# Altium schematic sheet NandFlash Board(A).SchDoc("NandFlash Board(A)")
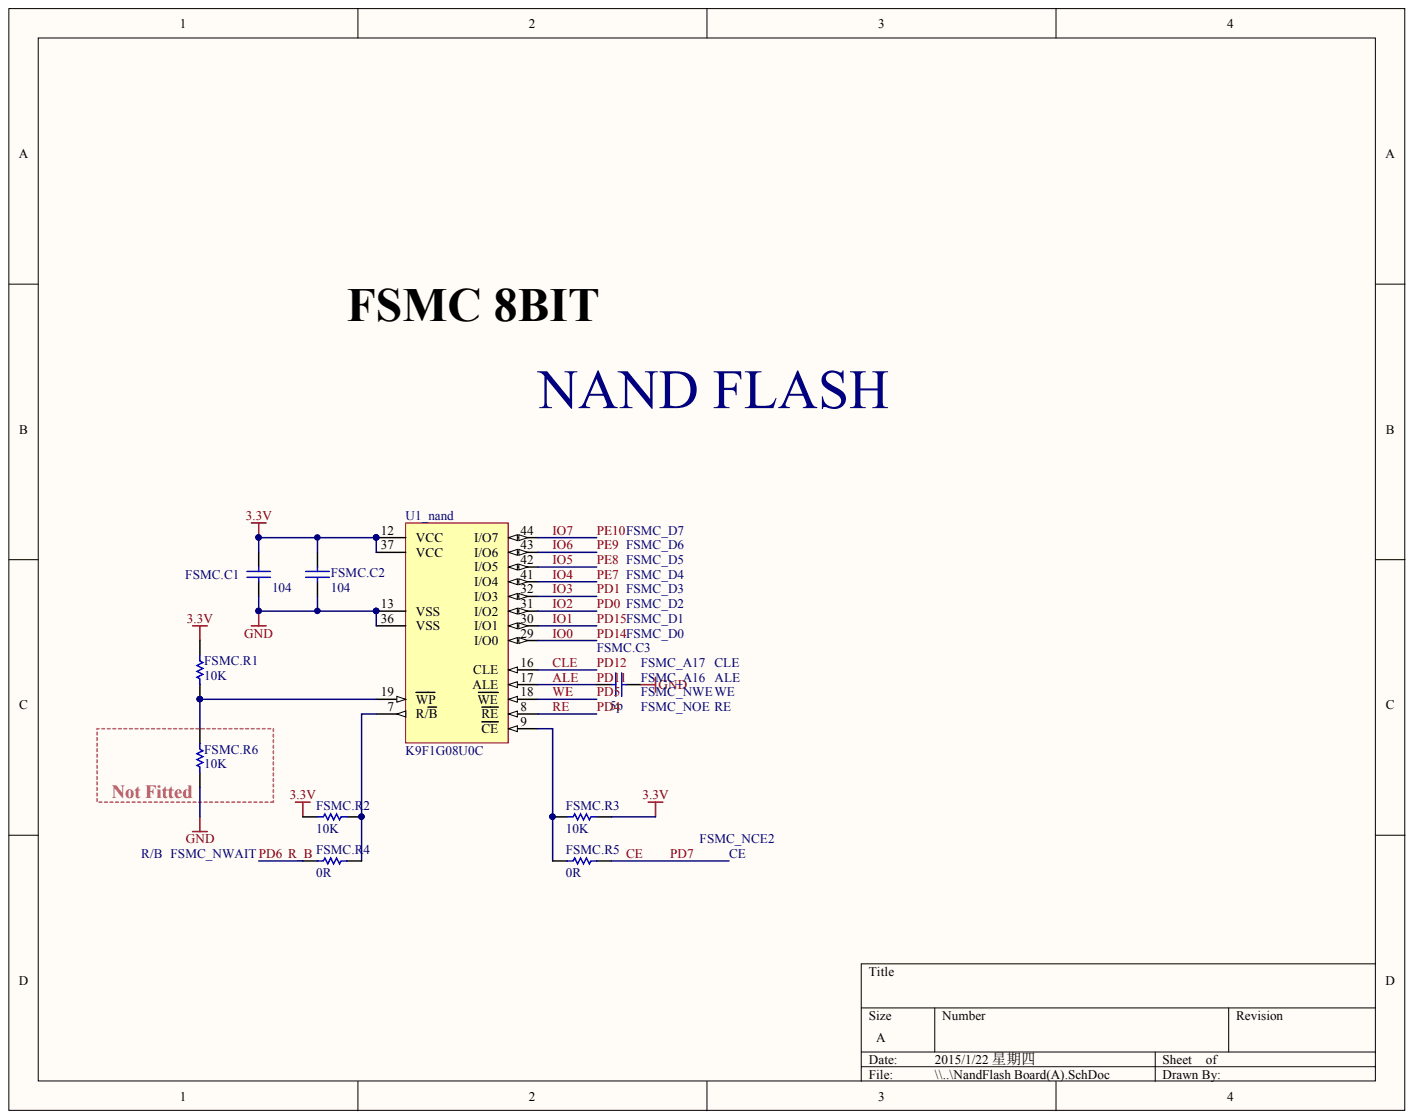
[diagram p0: NandFlash Board]

## Parts
FSMC.C1  104
FSMC.C2  104
FSMC.C3  5p
FSMC.R1  10K
FSMC.R2  10K
FSMC.R3  10K
FSMC.R4  0R
FSMC.R5  0R
FSMC.R6  10K
U1_nand  K9F1G08U0C

## Nets
3.3V: FSMC.C1.1 FSMC.C2.1 FSMC.R1.2 FSMC.R2.2 FSMC.R3.2 U1_nand.12(VCC) U1_nand.37(VCC)
GND: FSMC.C1.2 FSMC.C2.2 FSMC.C3.2 FSMC.R6.1 U1_nand.13(VSS) U1_nand.36(VSS)
ALE: FSMC.C3.1 U1_nand.17(ALE)
CE: FSMC.R5.2
CLE: U1_nand.16(CLE)
IO0: U1_nand.29(I/O0)
IO1: U1_nand.30(I/O1)
IO2: U1_nand.31(I/O2)
IO3: U1_nand.32(I/O3)
IO4: U1_nand.41(I/O4)
IO5: U1_nand.42(I/O5)
IO6: U1_nand.43(I/O6)
IO7: U1_nand.44(I/O7)
PD4: U1_nand.8(RE)
PD5: U1_nand.18(WE)
PD6: FSMC.R4.1
NetFSMC.R1_1: FSMC.R1.1 FSMC.R6.2 U1_nand.19(WP)
NetFSMC.R2_1: FSMC.R2.1 FSMC.R4.2 U1_nand.7(R/B)
NetFSMC.R3_1: FSMC.R3.1 FSMC.R5.1 U1_nand.9(CE)

## Library data of the parts
FSMC.C1-FSMC.C3 = (no symbol name): Value: 100pF
FSMC.R1-FSMC.R6 = (no symbol name): Value: 1K; Published: 8-Jun-2000; LatestRevisionDate: 17-Jul-2002; LatestRevisionNote: Re-released for DXP Platform.; PackageReference: AXIAL-0.3; Publisher: Altium Limited
